# Altium schematic sheet 01 PX4-Power-V1.0.SchDoc("01 PX4-Power-V1.0")
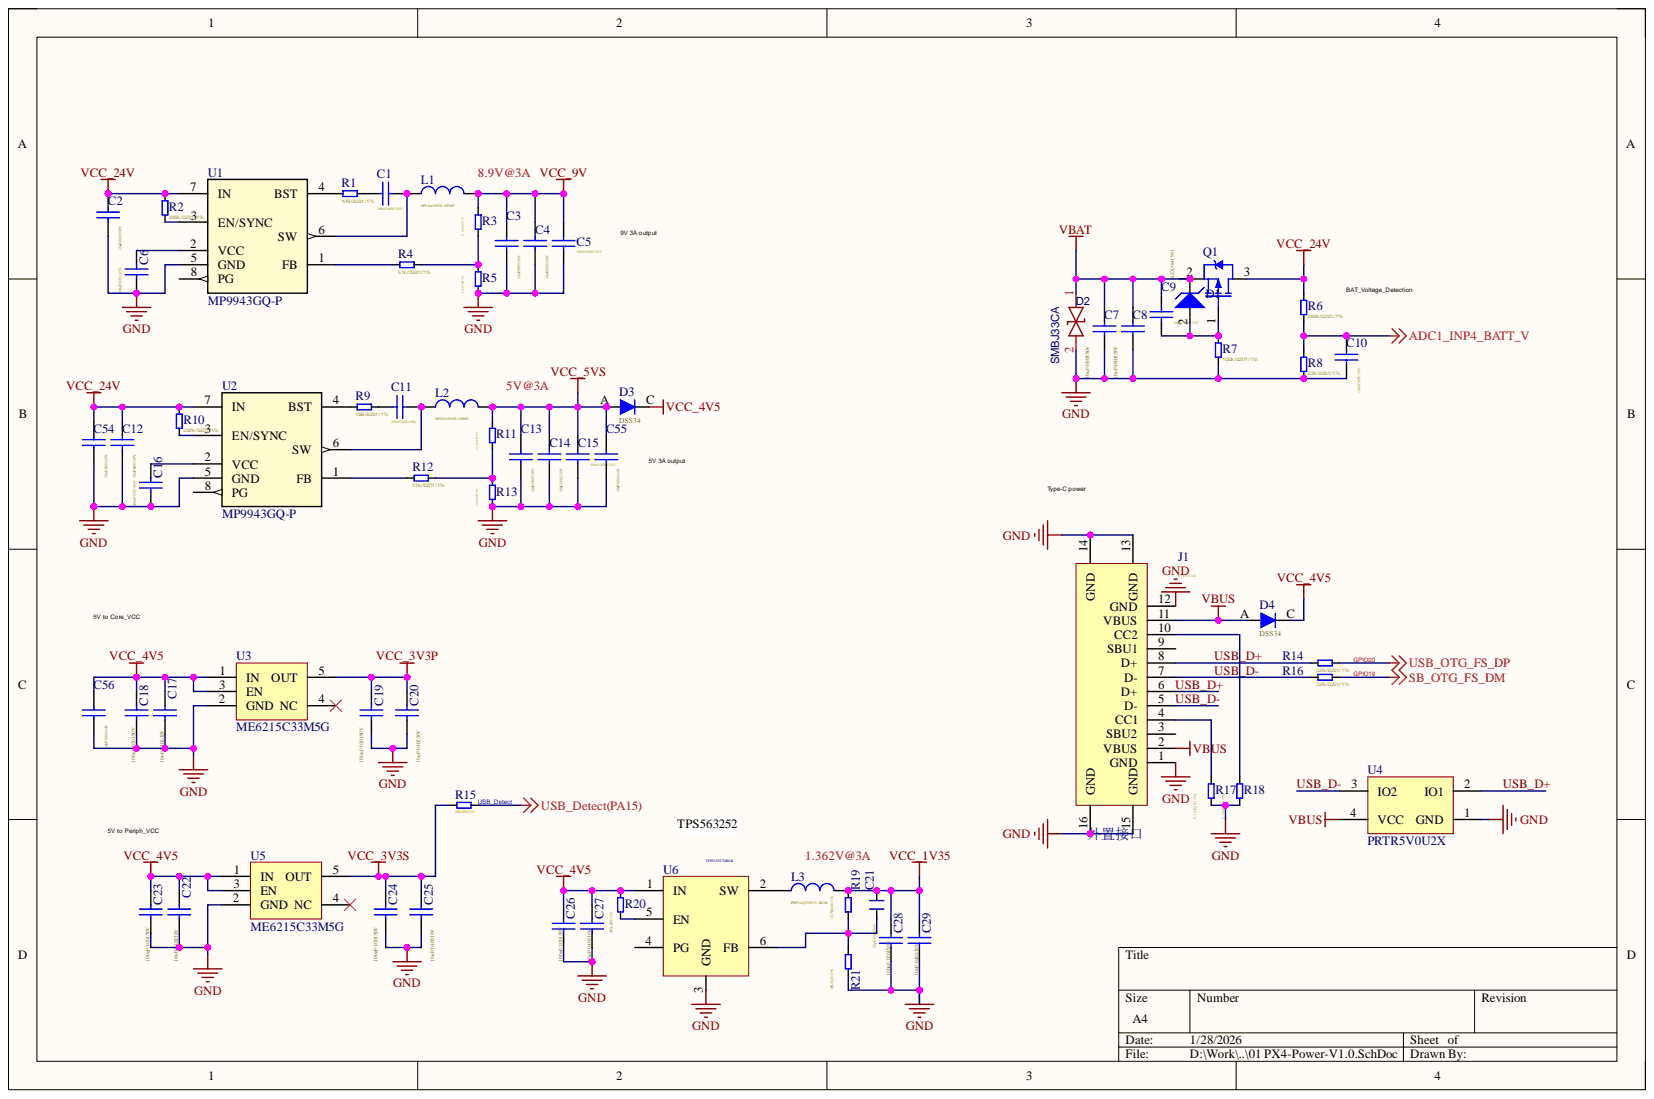
[diagram p0: 01 PX4-Power-V1.0.SchDoc]

## Parts
C1  100nF/0402/50V  [C0402]
C2  10uF/0805/50V  [C0805]
C3  10uF/0805/50V  [C0805]
C4  10uF/0805/50V  [C0805]
C5  100nF/0201/25V  [C0201]
C6  100nF/0201/6.3V  [C0201]
C7  10uF/0805/50V  [C0805]
C8  10uF/0805/50V  [C0805]
C9  100nF/0201/25V  [C0201]
C10  100nF/0201/50V  [C0201]
C11  100nF/0402/50V  [C0402]
C12  10uF/0805/50V  [C0805]
C13  10uF/0805/16V  [C0805]
C14  10uF/0805/16V  [C0805]
C15  100nF/0201/25V  [C0201]
C16  100nF/0201/6.3V  [C0201]
C17  10uF/0402/10V  [C0402]
C18  100nF/0201/50V  [C0201]
C19  100nF/0201/50V  [C0201]
C20  10uF/0402/10V  [C0402]
C21  22pF/0201/50V  [C0201]
C22  10uF/0402/10V  [C0402]
C23  100nF/0201/50V  [C0201]
C24  100nF/0201/50V  [C0201]
C25  10uF/0402/10V  [C0402]
C26  100nF/0201/50V  [C0201]
C27  10uF/0402/10V  [C0402]
C28  100nF/0201/50V  [C0201]
C29  10uF/0402/10V  [C0402]
C54  10uF/0805/50V  [C0805]
C55  10uF/0805/16V  [C0805]
C56  10uF/0805/16V  [C0805]
D1  BZX584C9V1  [SOD-523]
D2  SMBJ33CA  [SMB_L4.6-W3.6-LS5.3-RD]
D3  DSS34  [SOD-123FL_L2.8-W1.8-LS3.7-AC]
D4  DSS34  [SOD-123FL_L2.8-W1.8-LS3.7-AC]
J1  TYPE-C 16  [USB type C-16pin]
L1  MWSA0503S-100MT  [IND-SMD_L5.4-W5.2]
L2  MWSA0503S-100MT  [IND-SMD_L5.4-W5.2]
L3  PSPNAQ252012-2R2M  [L2520]
Q1  AP40P05  [SOT-23]
R1  10R/0201/1%  [R0201]
R2  200k/0201/1%  [R0201]
R3  41.2k/0201/1%  [R0201]
R4  51k/0201/1%  [R0201]
R5  4.02k/0201/1%  [R0201]
R6  200k/0201/1%  [R0201]
R7  100k/0201/1%  [R0201]
R8  22k/0201/1%  [R0201]
R9  10R/0201/1%  [R0201]
R10  200k/0201/1%  [R0201]
R11  41.2k/0201/1%  [R0201]
R12  51k/0201/1%  [R0201]
R13  7.68k/0201/1%  [R0201]
R14  22R/0201/1%  [R0201]
R15  10k/0201/1%  [R0201]
R16  22R/0201/1%  [R0201]
R17  5.1k/0201/1%  [R0201]
R18  5.1k/0201/1%  [R0201]
R19  12.7k/0201/1%  [R0201]
R20  100k/0201/1%  [R0201]
R21  10K/0201/1%  [R0201]
U1  MP9943GQ-P  [QFN-8_MP9943_MNP]
U2  MP9943GQ-P  [QFN-8_MP9943_MNP]
U3  ME6215C33M5G  [SOT23-5-S]
U4  PRTR5V0U2X  [SOT143B]
U5  ME6215C33M5G  [SOT23-5-S]
U6  TPS563257DRLR  [SOT563-2]

## Nets
ADC1_INP4_BATT_V: C10.1 R6.2 R8.1
GND: C2.1 C3.1 C4.1 C5.2 C6.1 C7.1 C8.1 C10.2 C12.1 C13.1 C14.1 C15.2 C16.1 C17.1 C18.1 C19.1 C20.1 C22.1 C23.1 C24.1 C25.1 C26.1 C27.1 C28.1 C29.1 C54.1 C55.1 C56.1 D2.2 J1.1(GND) J1.12(GND) J1.13(GND) J1.14(GND) J1.15(GND) J1.16(GND) R5.1 R7.2 R8.2 R13.1 R17.1 R18.1 R21.1 U1.5(GND) U2.5(GND) U3.2(GND) U4.1(GND) U5.2(GND) U6.3(GND)
VBAT: C7.2 C8.2 C9.2 D1.1 D2.1 Q1.2
VBUS: D4.A J1.2(VBUS) J1.11(VBUS) U4.4(VCC)
VCC_1V35: C21.2 C28.2 C29.2 L3.2 R19.2
VCC_3V3P: C19.2 C20.2 U3.5(OUT)
VCC_3V3S: C24.2 C25.2 R15.2 U5.5(OUT)
VCC_4V5: C17.2 C18.2 C22.2 C23.2 C26.2 C27.2 C56.2 D3.C D4.C R20.2 U3.1(IN) U3.3(EN) U5.1(IN) U5.3(EN) U6.1(IN)
VCC_5VS: C13.2 C14.2 C15.1 C55.2 D3.A L2.2 R11.2
VCC_9V: C3.2 C4.2 C5.1 L1.2 R3.2
VCC_24V: C2.2 C12.2 C54.2 Q1.3 R2.1 R6.1 R10.1 U1.7(IN) U2.7(IN)
GPIO19: R16.1
GPIO20: R14.1
USB_D+: J1.6(D+) J1.8(D+) R14.2 U4.2(IO1)
USB_D-: J1.5(D-) J1.7(D-) R16.2 U4.3(IO2)
USB_Detect: R15.1
NetC1_1: C1.1 R1.2
NetC1_2: C1.2 L1.1 U1.6(SW)
NetC6_2: C6.2 U1.2(VCC)
NetC9_1: C9.1 D1.2 Q1.1 R7.1
NetC11_1: C11.1 R9.2
NetC11_2: C11.2 L2.1 U2.6(SW)
NetC16_2: C16.2 U2.2(VCC)
NetC21_1: C21.1 R19.1 R21.2 U6.6(FB)
NetJ1_4: J1.4(CC1) R17.2
NetJ1_10: J1.10(CC2) R18.2
NetL3_1: L3.1 U6.2(SW)
NetR1_1: R1.1 U1.4(BST)
NetR2_2: R2.2 U1.3(EN/SYNC)
NetR3_1: R3.1 R4.1 R5.2
NetR4_2: R4.2 U1.1(FB)
NetR9_1: R9.1 U2.4(BST)
NetR10_2: R10.2 U2.3(EN/SYNC)
NetR11_1: R11.1 R12.1 R13.2
NetR12_2: R12.2 U2.1(FB)
NetR20_1: R20.1 U6.5(EN)

Not connected: J1 3(SBU2) 9(SBU1); U1 8(PG); U2 8(PG); U3 4(NC); U5 4(NC); U6 4(PG)

## Library data of the parts
C1 C9-C11 C18 C19 C23 C24 C26 C28 = Cap: Value: 100nF/0603/50V; Component Kind: Standard; LatestRevisionDate: 17-Jul-2002; LatestRevisionNote: Re-released for DXP Platform.; Library Name: Miscellaneous Devices.IntLib; PackageDescription: Radial Cap, Thru-Hole; 2 Leads; 0.3 in Pin Spacing; PackageReference: RAD-0.3; Published: 8-Jun-2000; Signal Integrity: Cap; Simulation: CAP; Publisher: Altium Limited
  C1 C11: Description: 100nF/0402/50V
  C9: Description: 100nF/0201/25V
  C10 C18 C19 C23 C24 C26 C28: Description: 100nF/0201/50V
C2-C4 C7 C8 C12-C14 C54-C56 = Cap: Value: 4.7uF/0805/50V; Component Kind: Standard; LatestRevisionDate: 17-Jul-2002; LatestRevisionNote: Re-released for DXP Platform.; Library Name: Miscellaneous Devices.IntLib; PackageDescription: Radial Cap, Thru-Hole; 2 Leads; 0.3 in Pin Spacing; PackageReference: RAD-0.3; Published: 8-Jun-2000; Signal Integrity: Cap; Simulation: CAP; Publisher: Altium Limited
  C2-C4 C7 C8 C12 C54: Description: 10uF/0805/50V
  C13 C14 C55 C56: Description: 10uF/0805/16V
C5 C15 = Cap: 100nF/0402/50V; Value: 100nF/0603/50V; Component Kind: Standard; LatestRevisionDate: 17-Jul-2002; LatestRevisionNote: Re-released for DXP Platform.; Library Name: Miscellaneous Devices.IntLib; PackageDescription: Radial Cap, Thru-Hole; 2 Leads; 0.3 in Pin Spacing; PackageReference: RAD-0.3; Published: 8-Jun-2000; Signal Integrity: Cap; Simulation: CAP; Publisher: Altium Limited
C6 C16 = Cap: Value: 100nF/0402/50V; Component Kind: Standard; Description: 100nF/0201/6.3V; LatestRevisionDate: 17-Jul-2002; LatestRevisionNote: Re-released for DXP Platform.; Library Name: Miscellaneous Devices.IntLib; PackageDescription: Radial Cap, Thru-Hole; 2 Leads; 0.3 in Pin Spacing; PackageReference: RAD-0.3; Published: 8-Jun-2000; Publisher: Altium Limited
C17 C22 = Cap: Value: 1uF/0603/50V; Component Kind: Standard; Description: 10uF/0402/10V; LatestRevisionDate: 17-Jul-2002; LatestRevisionNote: Re-released for DXP Platform.; Library Name: Miscellaneous Devices.IntLib; PackageDescription: Radial Cap, Thru-Hole; 2 Leads; 0.3 in Pin Spacing; PackageReference: RAD-0.3; Published: 8-Jun-2000; Signal Integrity: Cap; Simulation: CAP; Publisher: Altium Limited
C20 C25 C27 C29 = Cap: Value: 4.7uF/0603/50V; Component Kind: Standard; Description: 10uF/0402/10V; LatestRevisionDate: 17-Jul-2002; LatestRevisionNote: Re-released for DXP Platform.; Library Name: Miscellaneous Devices.IntLib; PackageDescription: Radial Cap, Thru-Hole; 2 Leads; 0.3 in Pin Spacing; PackageReference: RAD-0.3; Published: 8-Jun-2000; Signal Integrity: Cap; Simulation: CAP; Publisher: Altium Limited
C21 = CAP: Component Kind: Standard; Description: 22pF/0201/50V; Library Name: ROBO_V01_2015.SchLib
D1 = D Zener: Component Kind: Standard; Description: BZX584C9V1; LatestRevisionDate: 17-Jul-2002; LatestRevisionNote: Re-released for DXP Platform.; Library Name: dm-sch_library.SchLib; PackageDescription: Diode, Thru-Hole; 2 Leads; 0.7 in Pin Spacing; PackageReference: DIODE-0.7; Published: 8-Jun-2000; Signal Integrity: D Zener; Simulation: ZENER; Publisher: Altium Limited
D2 = SMCJ60CA_C310070: BOM_Manufacturer: Brightking Elec (TAIWAN); Contributor: LCSC; BOM_Manufacturer Part: SMCJ60CA; BOM_Supplier: LCSC; BOM_Supplier Part: C310070; Component Kind: Standard; Library Name: dm-sch_library.SchLib; spicePre: D; spiceSymbolName: SMCJ60CA_C310070
D3 D4 = DIODE: Component Kind: Standard; Description: DSS34; Library Name: ROBO_V01_2015.SchLib
J1 = USB TYPE-C-16: Component Kind: Standard; Library Name: Schlib1.SchLib
L1-L3 = Inductor: Component Kind: Standard; Library Name: ROBO_V01_2015.SchLib
  L1 L2: Description: MWSA0503S-100MT
Q1 = AO3401: Component Kind: Standard; Description: AP40P05; Library Name: dm-sch_library.SchLib
R1-R3 R6 R9-R11 R13-R21 = R: Component Kind: Standard; Library Name: ROBO_V01_2015.SchLib
  R1 R9: Description: 10R/0201/1%
  R2 R6 R10: Description: 200k/0201/1%
  R3 R11: Description: 41.2k/0201/1%
  R13: Description: 7.68k/0201/1%
  R14 R16: Description: 22R/0201/1%
  R15: Description: 10k/0201/1%
  R17 R18: Description: 5.1k/0201/1%
  R19: Description: 12.7k/0201/1%
  R20: Description: 100k/0201/1%
  R21: Description: 10K/0201/1%
R4 R5 R7 R8 R12 = R: 200k/0201/1%; Component Kind: Standard; Library Name: ROBO_V01_2015.SchLib
U1 U2 = MP9943GQ-P: High-Efficiency 3A Peak 36V Synchronous Step Down Converter with Power Good; Manufacturer_Name: Monolithic Power Systems; Component Kind: Standard; Datasheet: https://www.monolithicpower.com/en/documentview/productdocument/index/version/2/document_type/Datasheet/lang/en/sku/MP9943GQ-Z; Library Name: dm-sch_library.SchLib; Manufacturer_Part_Number: MP9943GQ-P; RefDes: RefDes; Type: Type; Copyright: Copyright (C) 2025 Ultra Librarian. All rights reserved.
U3 U5 = LP5907-3.3V: Component Kind: Standard; Library Name: ROBO_V01_2015.SchLib
U4 = PRTR5V0U2X: Component Kind: Standard; Library Name: ROBO_V01_2015.SchLib
U6 = TPS563257DRLR: Component Kind: Standard; Description: TPS563257DRLR; Library Name: dm-sch_library.SchLib
